# Altium schematic sheet Digital Pot U3 and U4 and U5.SchDoc
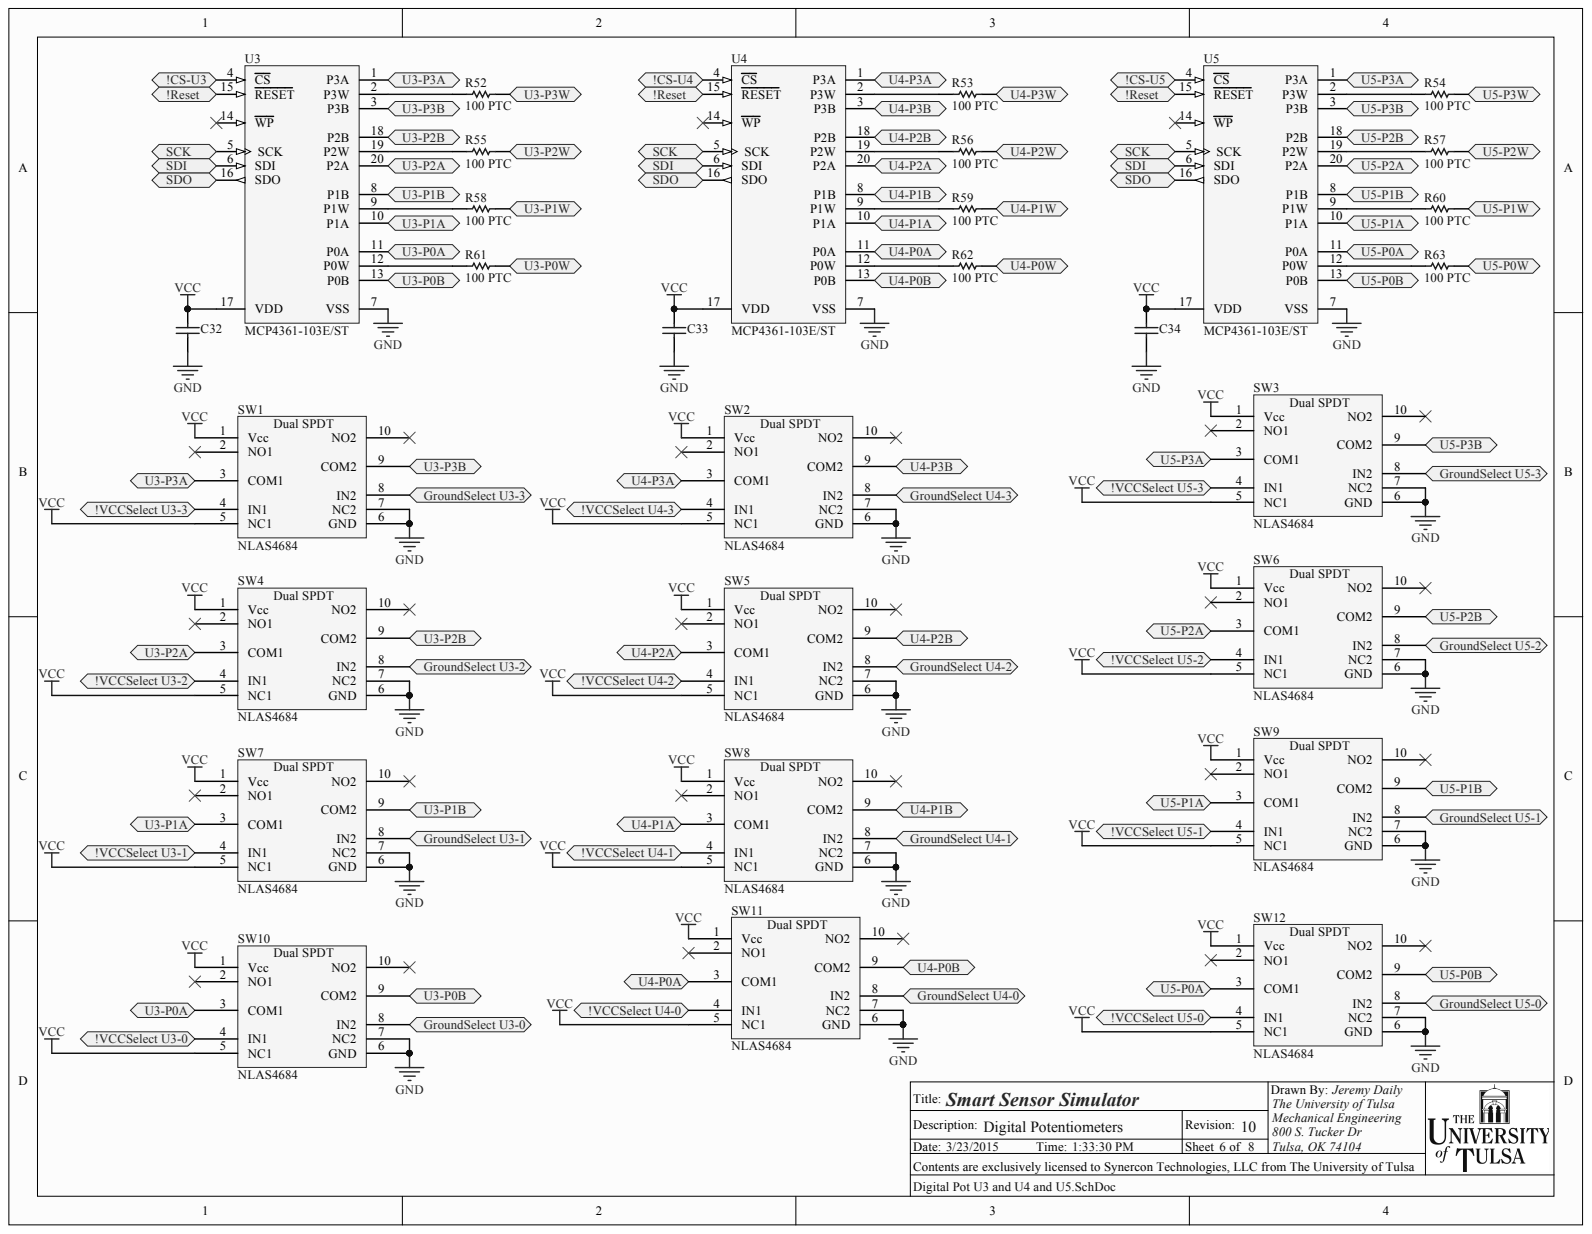
[diagram p0: Digital Pot U3 and U4 and U5.SchDoc]

## Parts
C32  0.1uF  [0603]  (value not drawn)
C33  0.1uF  [0603]  (value not drawn)
C34  0.1uF  [0603]  (value not drawn)
R52  100 PTC  [0603]
R53  100 PTC  [0603]
R54  100 PTC  [0603]
R55  100 PTC  [0603]
R56  100 PTC  [0603]
R57  100 PTC  [0603]
R58  100 PTC  [0603]
R59  100 PTC  [0603]
R60  100 PTC  [0603]
R61  100 PTC  [0603]
R62  100 PTC  [0603]
R63  100 PTC  [0603]
SW1  NLAS4684  [ONSC-MICRO-10-846B-03_V]
SW2  NLAS4684  [ONSC-MICRO-10-846B-03_V]
SW3  NLAS4684  [ONSC-MICRO-10-846B-03_V]
SW4  NLAS4684  [ONSC-MICRO-10-846B-03_V]
SW5  NLAS4684  [ONSC-MICRO-10-846B-03_V]
SW6  NLAS4684  [ONSC-MICRO-10-846B-03_V]
SW7  NLAS4684  [ONSC-MICRO-10-846B-03_V]
SW8  NLAS4684  [ONSC-MICRO-10-846B-03_V]
SW9  NLAS4684  [ONSC-MICRO-10-846B-03_V]
SW10  NLAS4684  [ONSC-MICRO-10-846B-03_V]
SW11  NLAS4684  [ONSC-MICRO-10-846B-03_V]
SW12  NLAS4684  [ONSC-MICRO-10-846B-03_V]
U3  MCP4361-103E/ST  [TSSOP-ST20_M]
U4  MCP4361-103E/ST  [TSSOP-ST20_M]
U5  MCP4361-103E/ST  [TSSOP-ST20_M]

## Ports
!CS-U3, !CS-U4, !CS-U5, !RESET, !VCCSELECT U3-0, !VCCSELECT U3-1, !VCCSELECT U3-2, !VCCSELECT U3-3, !VCCSELECT U4-0, !VCCSELECT U4-1, !VCCSELECT U4-2, !VCCSELECT U4-3, !VCCSELECT U5-0, !VCCSELECT U5-1, !VCCSELECT U5-2, !VCCSELECT U5-3, GROUNDSELECT U3-0, GROUNDSELECT U3-1, GROUNDSELECT U3-2, GROUNDSELECT U3-3, GROUNDSELECT U4-0, GROUNDSELECT U4-1, GROUNDSELECT U4-2, GROUNDSELECT U4-3, GROUNDSELECT U5-0, GROUNDSELECT U5-1, GROUNDSELECT U5-2, GROUNDSELECT U5-3, SCK, SDI, SDO, U3-P0A, U3-P0B, U3-P0W, U3-P1A, U3-P1B, U3-P1W, U3-P2A, U3-P2B, U3-P2W, U3-P3A, U3-P3B, U3-P3W, U4-P0A, U4-P0B, U4-P0W, U4-P1A, U4-P1B, U4-P1W, U4-P2A, U4-P2B, U4-P2W, U4-P3A, U4-P3B, U4-P3W, U5-P0A, U5-P0B, U5-P0W, U5-P1A, U5-P1B, U5-P1W, U5-P2A, U5-P2B, U5-P2W, U5-P3A, U5-P3B, U5-P3W

## Nets
GND: C32.2 C33.2 C34.2 SW1.6(GND) SW1.7(NC2) SW2.6(GND) SW2.7(NC2) SW3.6(GND) SW3.7(NC2) SW4.6(GND) SW4.7(NC2) SW5.6(GND) SW5.7(NC2) SW6.6(GND) SW6.7(NC2) SW7.6(GND) SW7.7(NC2) SW8.6(GND) SW8.7(NC2) SW9.6(GND) SW9.7(NC2) SW10.6(GND) SW10.7(NC2) SW11.6(GND) SW11.7(NC2) SW12.6(GND) SW12.7(NC2) U3.7(VSS) U4.7(VSS) U5.7(VSS)
VCC: C32.1 C33.1 C34.1 SW1.1(Vcc) SW1.5(NC1) SW2.1(Vcc) SW2.5(NC1) SW3.1(Vcc) SW3.5(NC1) SW4.1(Vcc) SW4.5(NC1) SW5.1(Vcc) SW5.5(NC1) SW6.1(Vcc) SW6.5(NC1) SW7.1(Vcc) SW7.5(NC1) SW8.1(Vcc) SW8.5(NC1) SW9.1(Vcc) SW9.5(NC1) SW10.1(Vcc) SW10.5(NC1) SW11.1(Vcc) SW11.5(NC1) SW12.1(Vcc) SW12.5(NC1) U3.17(VDD) U4.17(VDD) U5.17(VDD)
!CS-U3: U3.4(CS) ; port !CS-U3
!CS-U4: U4.4(CS) ; port !CS-U4
!CS-U5: U5.4(CS) ; port !CS-U5
SCK: U3.5(SCK) U4.5(SCK) U5.5(SCK) ; port SCK
SDI: U3.6(SDI) U4.6(SDI) U5.6(SDI) ; port SDI
SDO: U3.16(SDO) U4.16(SDO) U5.16(SDO) ; port SDO
U3-P0A: SW10.3(COM1) U3.11(P0A) ; port U3-P0A
U3-P0B: SW10.9(COM2) U3.13(P0B) ; port U3-P0B
U3-P0W: R61.2 ; port U3-P0W
U3-P1A: SW7.3(COM1) U3.10(P1A) ; port U3-P1A
U3-P1B: SW7.9(COM2) U3.8(P1B) ; port U3-P1B
U3-P1W: R58.2 ; port U3-P1W
U3-P2A: SW4.3(COM1) U3.20(P2A) ; port U3-P2A
U3-P2B: SW4.9(COM2) U3.18(P2B) ; port U3-P2B
U3-P2W: R55.2 ; port U3-P2W
U3-P3A: SW1.3(COM1) U3.1(P3A) ; port U3-P3A
U3-P3B: SW1.9(COM2) U3.3(P3B) ; port U3-P3B
U3-P3W: R52.2 ; port U3-P3W
U4-P0A: SW11.3(COM1) U4.11(P0A) ; port U4-P0A
U4-P0B: SW11.9(COM2) U4.13(P0B) ; port U4-P0B
U4-P0W: R62.2 ; port U4-P0W
U4-P1A: SW8.3(COM1) U4.10(P1A) ; port U4-P1A
U4-P1B: SW8.9(COM2) U4.8(P1B) ; port U4-P1B
U4-P1W: R59.2 ; port U4-P1W
U4-P2A: SW5.3(COM1) U4.20(P2A) ; port U4-P2A
U4-P2B: SW5.9(COM2) U4.18(P2B) ; port U4-P2B
U4-P2W: R56.2 ; port U4-P2W
U4-P3A: SW2.3(COM1) U4.1(P3A) ; port U4-P3A
U4-P3B: SW2.9(COM2) U4.3(P3B) ; port U4-P3B
U4-P3W: R53.2 ; port U4-P3W
U5-P0A: SW12.3(COM1) U5.11(P0A) ; port U5-P0A
U5-P0B: SW12.9(COM2) U5.13(P0B) ; port U5-P0B
U5-P0W: R63.2 ; port U5-P0W
U5-P1A: SW9.3(COM1) U5.10(P1A) ; port U5-P1A
U5-P1B: SW9.9(COM2) U5.8(P1B) ; port U5-P1B
U5-P1W: R60.2 ; port U5-P1W
U5-P2A: SW6.3(COM1) U5.20(P2A) ; port U5-P2A
U5-P2B: SW6.9(COM2) U5.18(P2B) ; port U5-P2B
U5-P2W: R57.2 ; port U5-P2W
U5-P3A: SW3.3(COM1) U5.1(P3A) ; port U5-P3A
U5-P3B: SW3.9(COM2) U5.3(P3B) ; port U5-P3B
U5-P3W: R54.2 ; port U5-P3W
NetR52_1: R52.1 U3.2(P3W)
NetR53_1: R53.1 U4.2(P3W)
NetR54_1: R54.1 U5.2(P3W)
NetR55_1: R55.1 U3.19(P2W)
NetR56_1: R56.1 U4.19(P2W)
NetR57_1: R57.1 U5.19(P2W)
NetR58_1: R58.1 U3.9(P1W)
NetR59_1: R59.1 U4.9(P1W)
NetR60_1: R60.1 U5.9(P1W)
NetR61_1: R61.1 U3.12(P0W)
NetR62_1: R62.1 U4.12(P0W)
NetR63_1: R63.1 U5.12(P0W)
NetSW1_4: SW1.4(IN1) ; port !VCCSELECT U3-3
NetSW1_8: SW1.8(IN2) ; port GROUNDSELECT U3-3
NetSW2_4: SW2.4(IN1) ; port !VCCSELECT U4-3
NetSW2_8: SW2.8(IN2) ; port GROUNDSELECT U4-3
NetSW3_4: SW3.4(IN1) ; port !VCCSELECT U5-3
NetSW3_8: SW3.8(IN2) ; port GROUNDSELECT U5-3
NetSW4_4: SW4.4(IN1) ; port !VCCSELECT U3-2
NetSW4_8: SW4.8(IN2) ; port GROUNDSELECT U3-2
NetSW5_4: SW5.4(IN1) ; port !VCCSELECT U4-2
NetSW5_8: SW5.8(IN2) ; port GROUNDSELECT U4-2
NetSW6_4: SW6.4(IN1) ; port !VCCSELECT U5-2
NetSW6_8: SW6.8(IN2) ; port GROUNDSELECT U5-2
NetSW7_4: SW7.4(IN1) ; port !VCCSELECT U3-1
NetSW7_8: SW7.8(IN2) ; port GROUNDSELECT U3-1
NetSW8_4: SW8.4(IN1) ; port !VCCSELECT U4-1
NetSW8_8: SW8.8(IN2) ; port GROUNDSELECT U4-1
NetSW9_4: SW9.4(IN1) ; port !VCCSELECT U5-1
NetSW9_8: SW9.8(IN2) ; port GROUNDSELECT U5-1
NetSW10_4: SW10.4(IN1) ; port !VCCSELECT U3-0
NetSW10_8: SW10.8(IN2) ; port GROUNDSELECT U3-0
NetSW11_4: SW11.4(IN1) ; port !VCCSELECT U4-0
NetSW11_8: SW11.8(IN2) ; port GROUNDSELECT U4-0
NetSW12_4: SW12.4(IN1) ; port !VCCSELECT U5-0
NetSW12_8: SW12.8(IN2) ; port GROUNDSELECT U5-0
NetU3_15: U3.15(RESET) U4.15(RESET) U5.15(RESET) ; port !RESET

Not connected: SW1 2(NO1) 10(NO2); SW2 2(NO1) 10(NO2); SW3 2(NO1) 10(NO2); SW4 2(NO1) 10(NO2); SW5 2(NO1) 10(NO2); SW6 2(NO1) 10(NO2); SW7 2(NO1) 10(NO2); SW8 2(NO1) 10(NO2); SW9 2(NO1) 10(NO2); SW10 2(NO1) 10(NO2); SW11 2(NO1) 10(NO2); SW12 2(NO1) 10(NO2); U3 14(WP); U4 14(WP); U5 14(WP)

## Library data of the parts
C32-C34 = Cap: CAP CER 0.1UF 50V 10% X7R 0603; Manufacturer: Murata Electronics North America; PartNumber: GRM188R71H104KA93D; Component Kind: Standard; Library Name: SSS Schematic Library.SchLib; Signal Integrity: Cap; Simulation: CAP; Supplier 1: Digi-Key; Supplier Part Number 1: 490-1519-1-ND
R52-R63 = Chip Resistor: THERMISTOR PTC 100 OHM SMD; Manufacturer: Murata Electronics North America; PartNumber: PRG18BB101MB1RB; Component Kind: Standard; Library Name: SSS Schematic Library.SchLib; Supplier 1: Digi-Key; Supplier Part Number 1: 490-2469-1-ND
SW1-SW12 = Dual SPDT: IC SWITCH DUAL SPDT MICRO10; Manufacturer: ON Semiconductor; PartNumber: NLAS4684MR2G; Component Kind: Standard; Library Name: SSS Schematic Library.SchLib; Mounting Technology: Surface Mount; Supplier 1: Digi-Key; Supplier Part Number 1: NLAS4684MR2GOSCT-ND
U3-U5 = MCP4361-103E/ST: 7/8-Bit Quad SPI Digital POT with Non-Volatile Memory, 20-Pin TSSOP, Extended Temperature; Manufacturer: Microchip; Component Kind: Standard; ComponentLink2URL: http://ww1.microchip.com/downloads/en/DeviceDoc/22233a.pdf; ComponentLink3Description: Package Specification; ComponentLink3URL: http://www.microchip.com/stellent/groups/techpub_sg/documents/packagingspec/en012702.pdf; DatasheetVersion: revA, Dec-2009; Mounting Technology: Surface Mount; PackageDescription: 20-Lead Plastic Thin Shrink Small Outline (ST) - 4.4mm Body [TSSOP]; PackageVersion: revBB, Aug-2009; PartNumber: MCP4361-103E/ST; Supplier 1: Digi-Key; Supplier 2: Farnell; Supplier 3: Newark; Supplier 4: Mouser; Supplier Part Number 1: MCP4361-103E/ST-ND; Supplier Part Number 2: 1825025; Supplier Part Number 3: 53R0040; Supplier Part Number 4: 579-MCP4361103E/ST; Library Name: Microchip Mixed Signal.IntLib
